# Altium schematic sheet PMI_Action.SchDoc
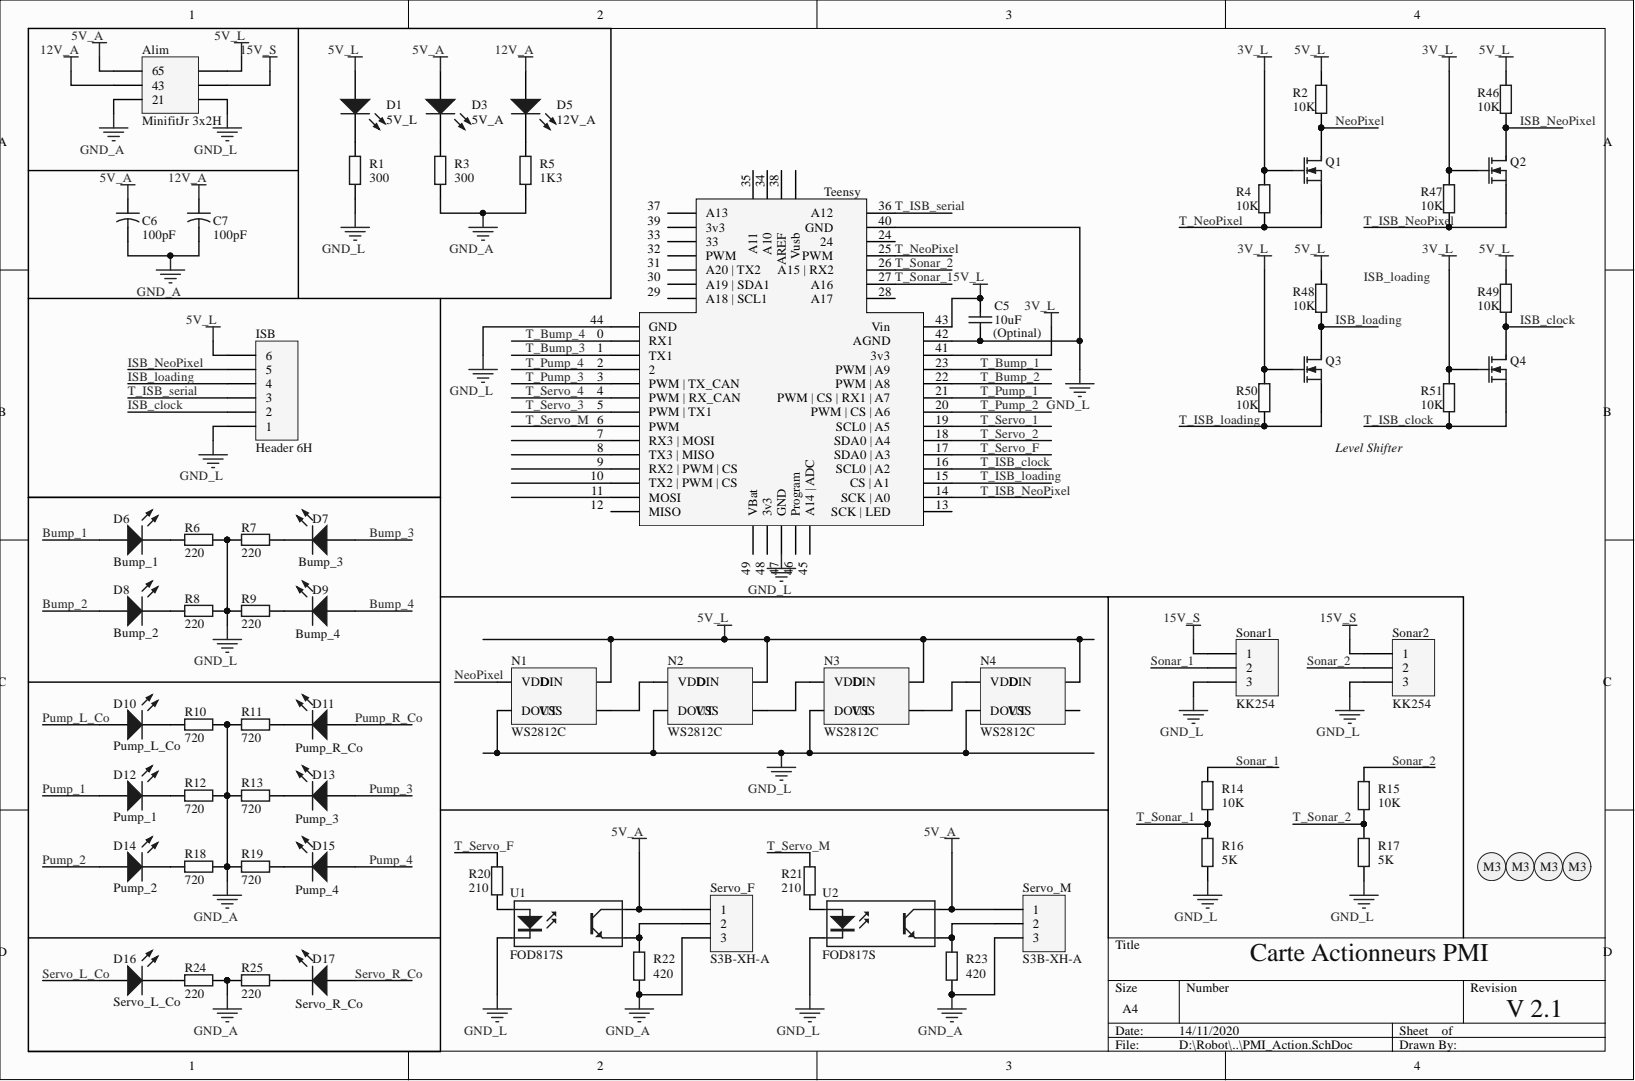
[diagram p0: PMI_Action.SchDoc]

## Parts
Alim  MinifitJr 3x2H  [MOLEX_MINIFITJR_3X2]
C5  (Optinal)  value 10uF  [Capacitor 1206]
C6  value 100pF  [Condensateur]  (hidden comment: Cap2)
C7  value 100pF  [Condensateur]  (hidden comment: Cap2)
D1  5V_L  [KP-3216SEC]
D3  5V_A  [KP-3216SEC]
D5  12V_A  [KP-3216SEC]
D6  Bump_1  [KP-3216SEC]
D7  Bump_3  [KP-3216SEC]
D8  Bump_2  [KP-3216SEC]
D9  Bump_4  [KP-3216SEC]
D10  Pump_L_Co  [KP-3216SEC]
D11  Pump_R_Co  [KP-3216SEC]
D12  Pump_1  [KP-3216SEC]
D13  Pump_3  [KP-3216SEC]
D14  Pump_2  [KP-3216SEC]
D15  Pump_4  [KP-3216SEC]
D16  Servo_L_Co  [KP-3216SEC]
D17  Servo_R_Co  [KP-3216SEC]
ISB  Header 6H  [S6B-XH-A]
N1  WS2812C  [WS2812C]
N2  WS2812C  [WS2812C]
N3  WS2812C  [WS2812C]
N4  WS2812C  [WS2812C]
Q1  MOSFET-N  [SOT23_M]  (value not drawn)
Q2  MOSFET-N  [SOT23_M]  (value not drawn)
Q3  MOSFET-N  [SOT23_M]  (value not drawn)
Q4  MOSFET-N  [SOT23_M]  (value not drawn)
R1  value 300  [Resistor 1206]  (hidden comment: Res2)
R2  value 10K  [Resistor 1206]  (hidden comment: Res2)
R3  value 300  [Resistor 1206]  (hidden comment: Res2)
R4  value 10K  [Resistor 1206]  (hidden comment: Res2)
R5  value 1K3  [Resistor 1206]  (hidden comment: Res2)
R6  value 220  [Resistor 1206]  (hidden comment: Res2)
R7  value 220  [Resistor 1206]  (hidden comment: Res2)
R8  value 220  [Resistor 1206]  (hidden comment: Res2)
R9  value 220  [Resistor 1206]  (hidden comment: Res2)
R10  value 720  [Resistor 1206]  (hidden comment: Res2)
R11  value 720  [Resistor 1206]  (hidden comment: Res2)
R12  value 720  [Resistor 1206]  (hidden comment: Res2)
R13  value 720  [Resistor 1206]  (hidden comment: Res2)
R14  value 10K  [Resistor 1206]  (hidden comment: Res2)
R15  value 10K  [Resistor 1206]  (hidden comment: Res2)
R16  value 5K  [Resistor 1206]  (hidden comment: Res2)
R17  value 5K  [Resistor 1206]  (hidden comment: Res2)
R18  value 720  [Resistor 1206]  (hidden comment: Res2)
R19  value 720  [Resistor 1206]  (hidden comment: Res2)
R20  value 210  [Resistor 1206]  (hidden comment: Res2)
R21  value 210  [Resistor 1206]  (hidden comment: Res2)
R22  value 420  [Resistor 1206]  (hidden comment: Res2)
R23  value 420  [Resistor 1206]  (hidden comment: Res2)
R24  value 220  [Resistor 1206]  (hidden comment: Res2)
R25  value 220  [Resistor 1206]  (hidden comment: Res2)
R46  value 10K  [Resistor 1206]  (hidden comment: Res2)
R47  value 10K  [Resistor 1206]  (hidden comment: Res2)
R48  value 10K  [Resistor 1206]  (hidden comment: Res2)
R49  value 10K  [Resistor 1206]  (hidden comment: Res2)
R50  value 10K  [Resistor 1206]  (hidden comment: Res2)
R51  value 10K  [Resistor 1206]  (hidden comment: Res2)
S1  Screw M3  [Screw M3]  (value not drawn)
S2  Screw M3  [Screw M3]  (value not drawn)
S3  Screw M3  [Screw M3]  (value not drawn)
S4  Screw M3  [Screw M3]  (value not drawn)
Servo_F  S3B-XH-A  [S3B-XH-A]
Servo_M  S3B-XH-A  [S3B-XH-A]
Sonar1  KK254  [MOLEX KK254 3X1H M]
Sonar2  KK254  [MOLEX KK254 3X1H M]
Teensy  Teensy 3.2  [teensy]  (value not drawn)
U1  FOD817S  [SOP254P1016X385-4N]
U2  FOD817S  [SOP254P1016X385-4N]

## Nets
3V_L: Q1.2 Q2.2 Q3.2 Q4.2 R4.2 R47.2 R50.2 R51.2 Teensy.41
5V_A: Alim.6(6_5) C6.1 D3.1 Servo_F.1 Servo_M.1 U1.4 U2.4
5V_L: Alim.5 C5.2 D1.1 ISB.6 N1.4 N2.4 N3.4 N4.4 R2.2 R46.2 R48.2 R49.2 Teensy.43
12V_A: Alim.4(4_3) C7.1 D5.1
15V_S: Alim.3 Sonar1.1 Sonar2.1
GND_A: Alim.2(2_1) C6.2 C7.2 R3.2 R5.2 R10.2 R11.2 R12.2 R13.2 R18.2 R19.1 R22.1 R23.1 R24.2 R25.2 Servo_F.3 Servo_M.3
GND_L: Alim.1 C5.1 ISB.1 N1.2(DOUT) N2.2(DOUT) N3.2(DOUT) N4.2(DOUT) R1.1 R6.2 R7.2 R8.2 R9.1 R16.2 R17.2 Sonar1.3 Sonar2.3 Teensy.40 Teensy.42 Teensy.44(GND) Teensy.47(GND) U1.2 U2.2
BUMP_1: D6.1
BUMP_2: D8.1
BUMP_3: D7.1
BUMP_4: D9.1
ISB_CLOCK: ISB.2 Q4.1 R49.1
ISB_LOADING: ISB.4 Q3.1 R48.1
ISB_NEOPIXEL: ISB.5 Q2.1 R46.1
NEOPIXEL: N1.1 Q1.1 R2.1
PUMP_1: D12.1
PUMP_2: D14.1
PUMP_3: D13.1
PUMP_4: D15.1
PUMP_L_CO: D10.1
PUMP_R_CO: D11.1
SERVO_L_CO: D16.1
SERVO_R_CO: D17.1
SONAR_1: R14.1 Sonar1.2
SONAR_2: R15.1 Sonar2.2
T_BUMP_1: Teensy.23
T_BUMP_2: Teensy.22
T_BUMP_3: Teensy.1(TX1)
T_BUMP_4: Teensy.0(RX1)
T_ISB_CLOCK: Q4.3 R51.1 Teensy.16
T_ISB_LOADING: Q3.3 R50.1 Teensy.15
T_ISB_NEOPIXEL: Q2.3 R47.1 Teensy.14
T_ISB_SERIAL: ISB.3 Teensy.36
T_NEOPIXEL: Q1.3 R4.1 Teensy.25
T_PUMP_1: Teensy.21
T_PUMP_2: Teensy.20
T_PUMP_3: Teensy.3(PWM_|_TX_CAN)
T_PUMP_4: Teensy.2
T_SERVO_1: Teensy.19
T_SERVO_2: Teensy.18
T_SERVO_3: Teensy.5(PWM_|_TX1)
T_SERVO_4: Teensy.4(PWM_|_RX_CAN)
T_SERVO_F: R20.2 Teensy.17
T_SERVO_M: R21.2 Teensy.6(PWM)
T_SONAR_1: R14.2 R16.1 Teensy.27
T_SONAR_2: R15.2 R17.1 Teensy.26
NetD1_2: D1.2 R1.2
NetD3_2: D3.2 R3.1
NetD5_2: D5.2 R5.1
NetD6_2: D6.2 R6.1
NetD7_2: D7.2 R7.1
NetD8_2: D8.2 R8.1
NetD9_2: D9.2 R9.2
NetD10_2: D10.2 R10.1
NetD11_2: D11.2 R11.1
NetD12_2: D12.2 R12.1
NetD13_2: D13.2 R13.1
NetD14_2: D14.2 R18.1
NetD15_2: D15.2 R19.2
NetD16_2: D16.2 R24.1
NetD17_2: D17.2 R25.1
NetN1_3: N1.3 N2.1
NetN2_3: N2.3 N3.1
NetN3_3: N3.3 N4.1
NetR20_1: R20.1 U1.1
NetR21_1: R21.1 U2.1
NetR22_2: R22.2 Servo_F.2 U1.3
NetR23_2: R23.2 Servo_M.2 U2.3

Not connected: N4 3; Teensy 7(RX3_|_MOSI) 8(TX3_|_MISO) 9(RX2_|_PWM_|_CS) 10(TX2_|_PWM_|_CS) 11(MOSI) 12(MISO) 13 24 28 29(A18_|_SCL1) 30(A19_|_SDA1) 31(A20_|_TX2) 32(PWM) 33 34 35 37(A13) 38 39(3v3) 45(A14_|_ADC) 46(Program) 48(3v3) 49(VBat) 50

## Library data of the parts
Alim = Header 3X2H: Header, 3-Pin, Dual row, Right Angle; Component Kind: Standard; LatestRevisionDate: 17-Jul-2002; LatestRevisionNote: Re-released for DXP Platform.; Library Name: Miscellaneous Connectors.IntLib; Signal Integrity: Connector; Publisher: Altium Limited
C5 = Cap: Capacitor; Component Kind: Standard; LatestRevisionDate: 17-Jul-2002; LatestRevisionNote: Re-released for DXP Platform.; Library Name: Miscellaneous Devices.IntLib; PackageDescription: Radial Cap, Thru-Hole; 2 Leads; 0.3 in Pin Spacing; PackageReference: RAD-0.3; Published: 8-Jun-2000; Signal Integrity: Cap; Simulation: CAP; Publisher: Altium Limited
C6 C7 = Cap2: Capacitor; Component Kind: Standard; Library Name: Miscellaneous Devices.IntLib; PackageDescription: Capacitor; 2 Leads; PackageReference: CAPR5-4X5; Published: 23-Sep-2002; Signal Integrity: Cap; Simulation: CAP; Publisher: Altium Limited
D1 D3 D5-D17 = LED2: Typical RED, GREEN, YELLOW, AMBER GaAs LED; Component Kind: Standard; LatestRevisionDate: 17-Jul-2002; LatestRevisionNote: Re-released for DXP Platform.; Library Name: Miscellaneous Devices.IntLib; PackageDescription: SM LED; 2 Flat Leads; PackageReference: 3.2X1.6X1.1; PackageVersion: Feb-2002; Published: 8-Jun-2000; Simulation: LED2; Publisher: Altium Limited
ISB = Header 6H: Header, 6-Pin, Right Angle; Component Kind: Standard; LatestRevisionDate: 17-Jul-2002; LatestRevisionNote: Re-released for DXP Platform.; Library Name: Miscellaneous Connectors.IntLib; Signal Integrity: Connector; Publisher: Altium Limited
N1-N4 = WS2812C: Intelligent control LED; Component Kind: Standard; Library Name: Robot_2020.SchLib
Q1-Q4 = MOSFET-N: N-Channel MOSFET; Component Kind: Standard; LatestRevisionDate: 17-Jul-2002; LatestRevisionNote: Re-released for DXP Platform.; Library Name: Miscellaneous Devices.IntLib; PackageDescription: TO, Flat Index; 3 In-Line, Axial Leads; Body Dia. 4.6mm; Lead Dia. 0.5 mm (max); PackageReference: E3; PackageVersion: 1995/1996; Published: 8-Jun-2000; Simulation: NMOS; Publisher: Altium Limited
R1-R25 R46-R51 = Res2: Resistor; Component Kind: Standard; LatestRevisionDate: 17-Jul-2002; LatestRevisionNote: Re-released for DXP Platform.; Library Name: Miscellaneous Devices.IntLib; PackageDescription: Axial Device, Thru-Hole; 2 Leads; 0.4 in Pin Spacing; PackageReference: AXIAL-0.4; Published: 8-Jun-2000; Signal Integrity: Res; Simulation: RESISTOR; Publisher: Altium Limited
Servo_F Servo_M Sonar1 Sonar2 = Header 3H: Header, 3-Pin, Right Angle; Component Kind: Standard; LatestRevisionDate: 17-Jul-2002; LatestRevisionNote: Re-released for DXP Platform.; Library Name: Miscellaneous Connectors.IntLib; Signal Integrity: Connector; Publisher: Altium Limited
Teensy = teensy3.2: Component Kind: Standard; Description: Teensy 3.2; Library Name: Robot_2020.SchLib
U1 U2 = Optoisolator1: 4-Pin Phototransistor Optocoupler; Component Kind: Standard; DatasheetVersion: Nov-2003; LatestRevisionDate: 04-Mar-2005; LatestRevisionNote: Sch pins 3 & 4 Swapped.; Library Name: Miscellaneous Devices.IntLib; PackageReference: DIP-4; Published: 24-Mar-1999; Publisher: Altium Limited
S1-S4 = Screw M3: Component Kind: Standard; Library Name: Robot_2020.SchLib
